# Altium schematic sheet ADC.SchDoc
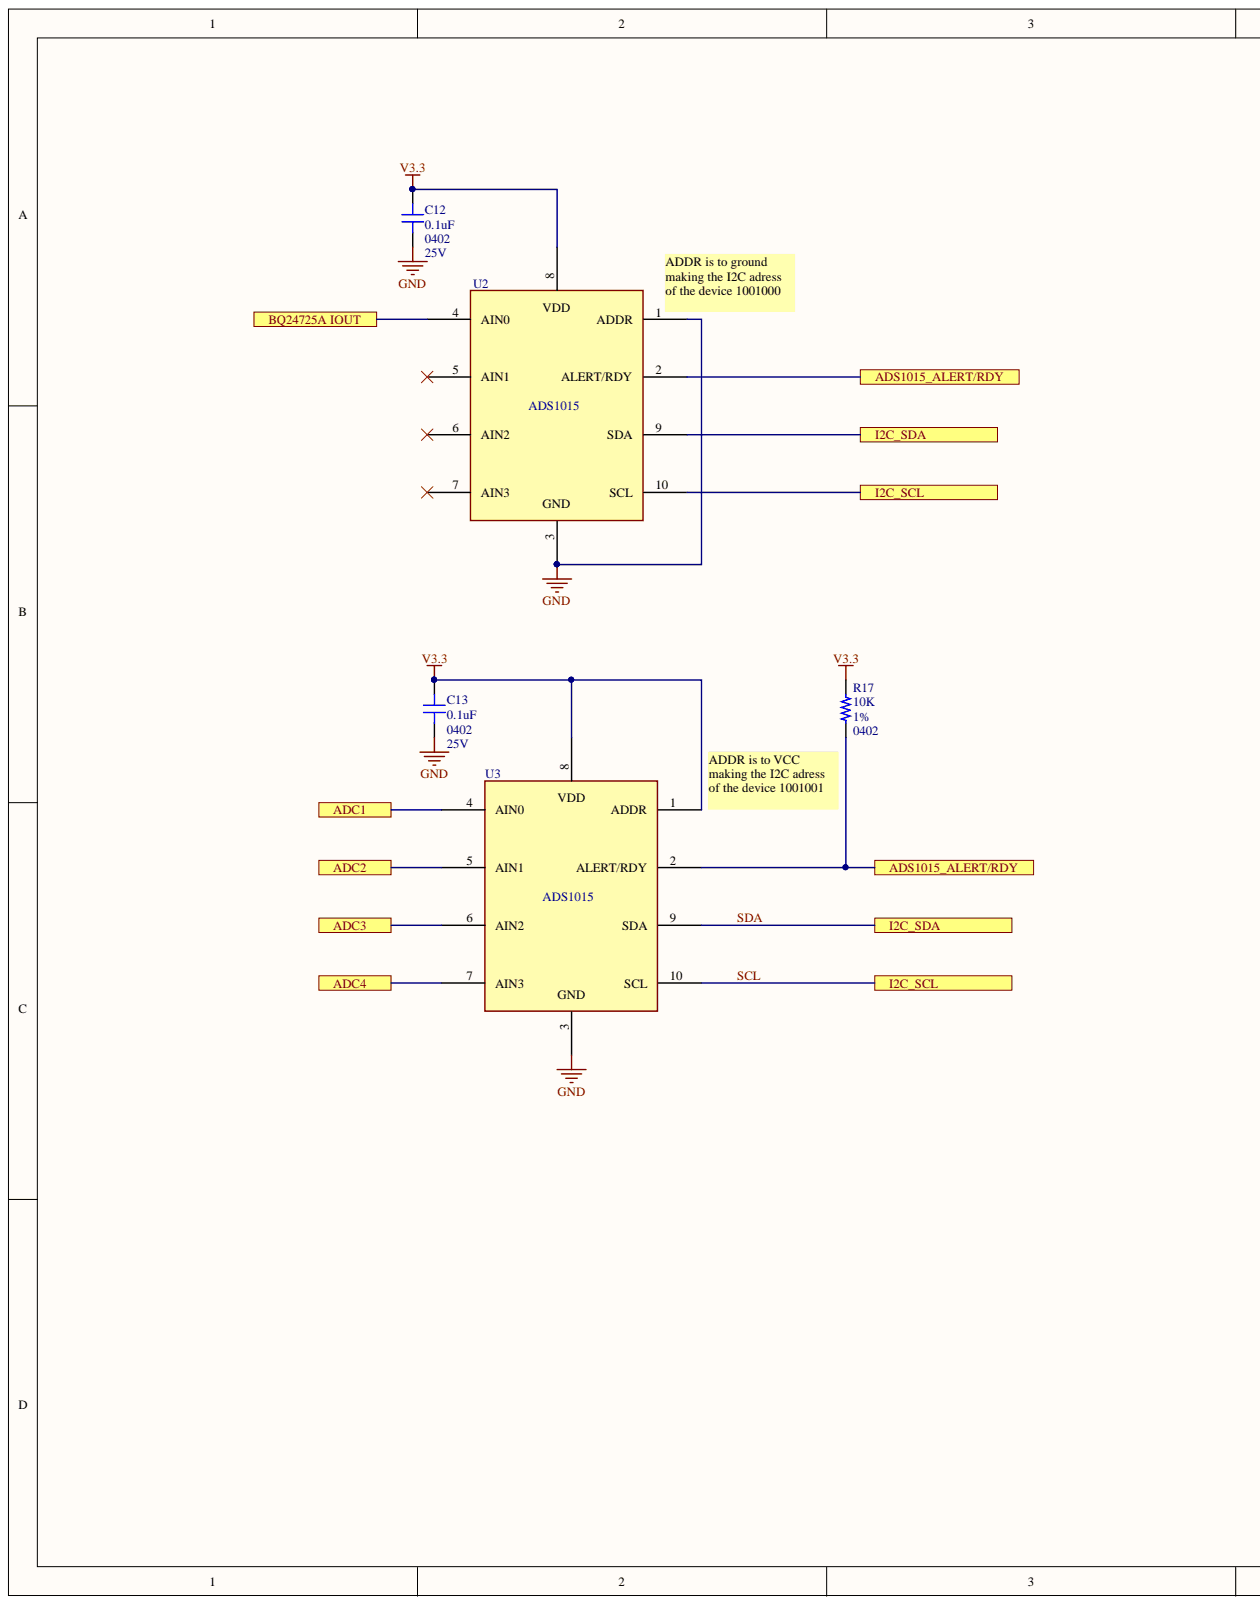
[diagram p0: ADC.SchDoc, left]
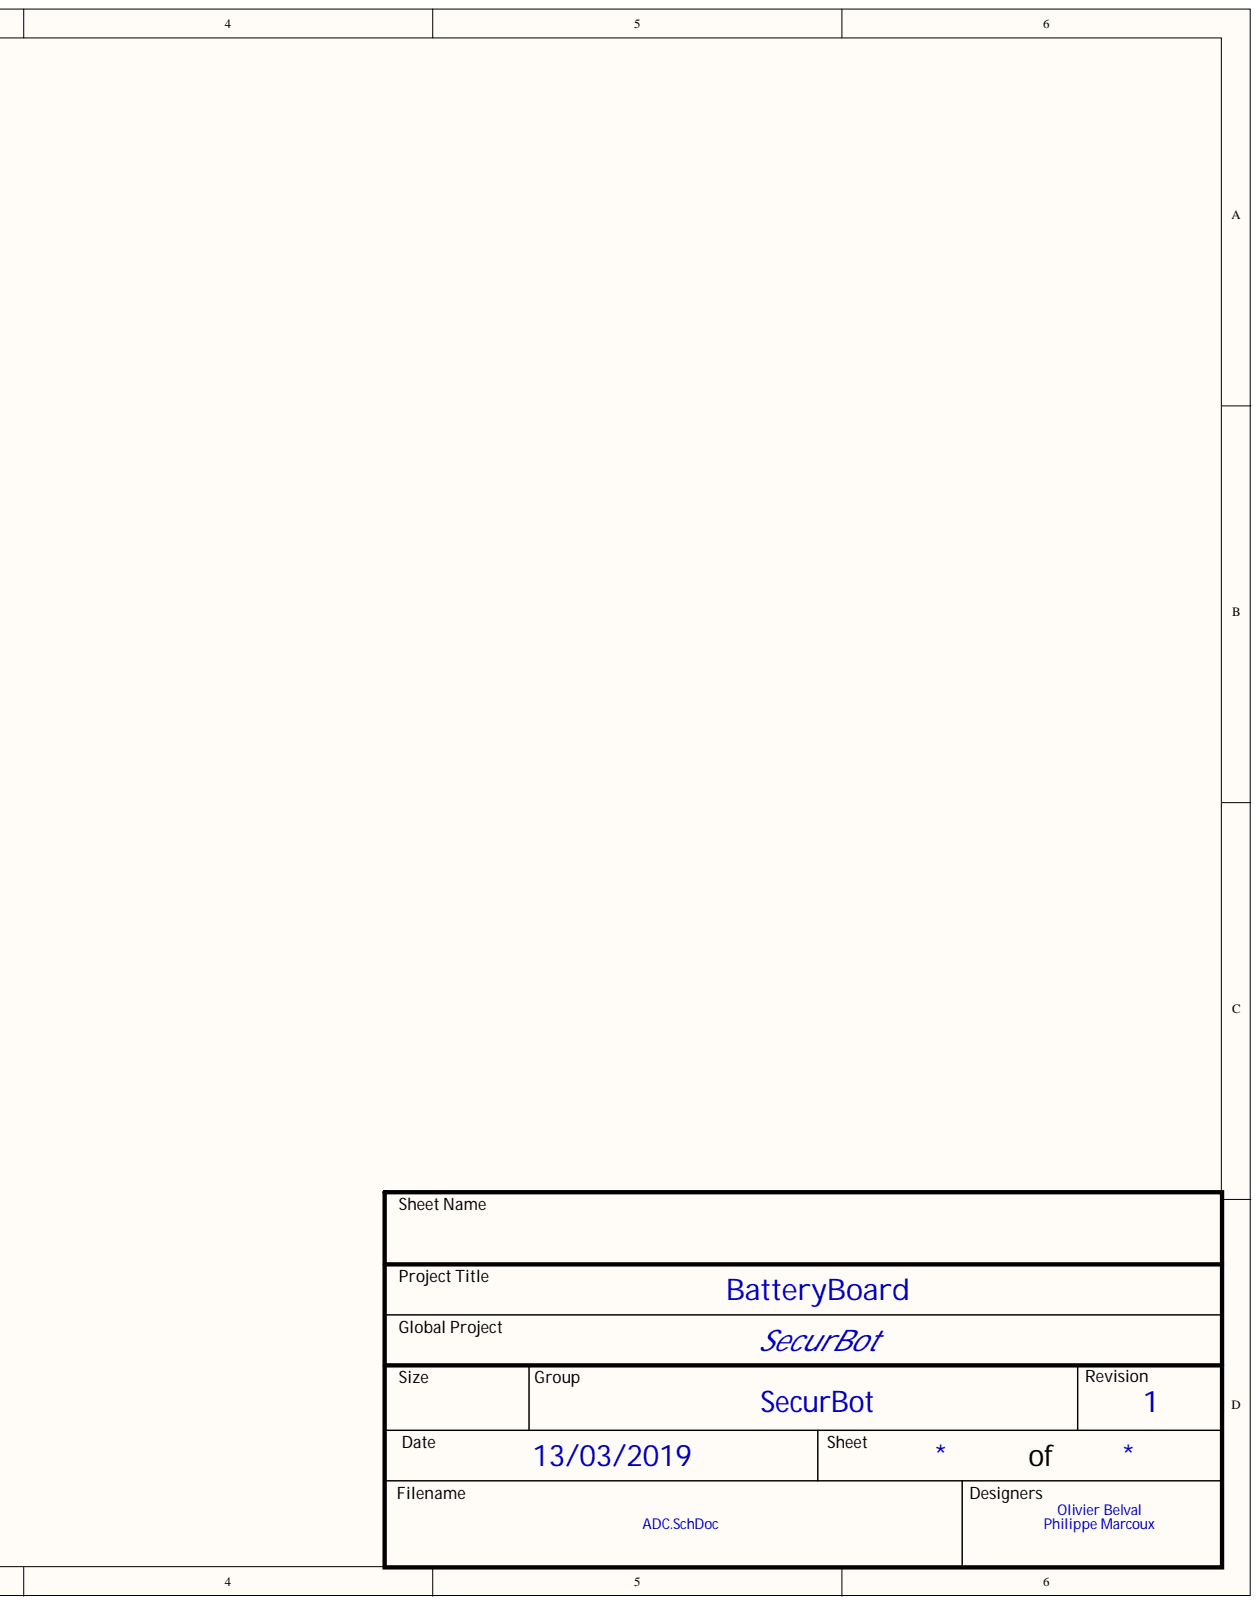
[diagram p1: ADC.SchDoc, right]

## Parts
C12  value 0.1uF  Package: 0402  Voltage: 25V  [CAP_0402]  (hidden comment: CAP - 0402)
C13  value 0.1uF  Package: 0402  Voltage: 25V  [CAP_0402]  (hidden comment: CAP - 0402)
R17  value 10K  Package: 0402  Tolerance: 1%  [RES_0402]  (hidden comment: RES - 0402)
U2  ADS1015  [ADS1015]
U3  ADS1015  [ADS1015]

## Ports
ADC1, ADC2, ADC3, ADC4, ADS1015_ALERT/RDY, BQ24725A IOUT, I2C_SCL, I2C_SDA

## Nets
GND: C12.2 C13.2 U2.1(ADDR) U2.3 U3.3
V3.3: C12.1 C13.1 R17.1 U2.8 U3.1(ADDR) U3.8
ADC1: U3.4(AIN0) ; port ADC1
ADC2: U3.5(AIN1) ; port ADC2
ADC3: U3.6(AIN2) ; port ADC3
ADC4: U3.7(AIN3) ; port ADC4
ADS1015_ALERT/RDY: R17.2 U2.2(ALERT/RDY) U3.2(ALERT/RDY) ; port ADS1015_ALERT/RDY
BQ24725A IOUT: U2.4(AIN0) ; port BQ24725A IOUT
SCL: U2.10(SCL) U3.10(SCL) ; port I2C_SCL
SDA: U2.9(SDA) U3.9(SDA) ; port I2C_SDA

Not connected: U2 5(AIN1) 6(AIN2) 7(AIN3)

## Library data of the parts
C12 C13 = CAP - 0402: Part number: CL05A104KA5NNNC; Component Kind: Standard; Description: CAP - 0402; Library Name: SecureBot.SchLib
R17 = RES - 0402: Part number: MCS0402MC1002FE000; Watt: ? W; Component Kind: Standard; Description: RES - 0402; Library Name: SecureBot.SchLib
U2 U3 = ADS1015: Component Kind: Standard; Part number: ADS1015; Library Name: SecureBot.SchLib
